# Altium schematic sheet left_led_gaze.SchDoc
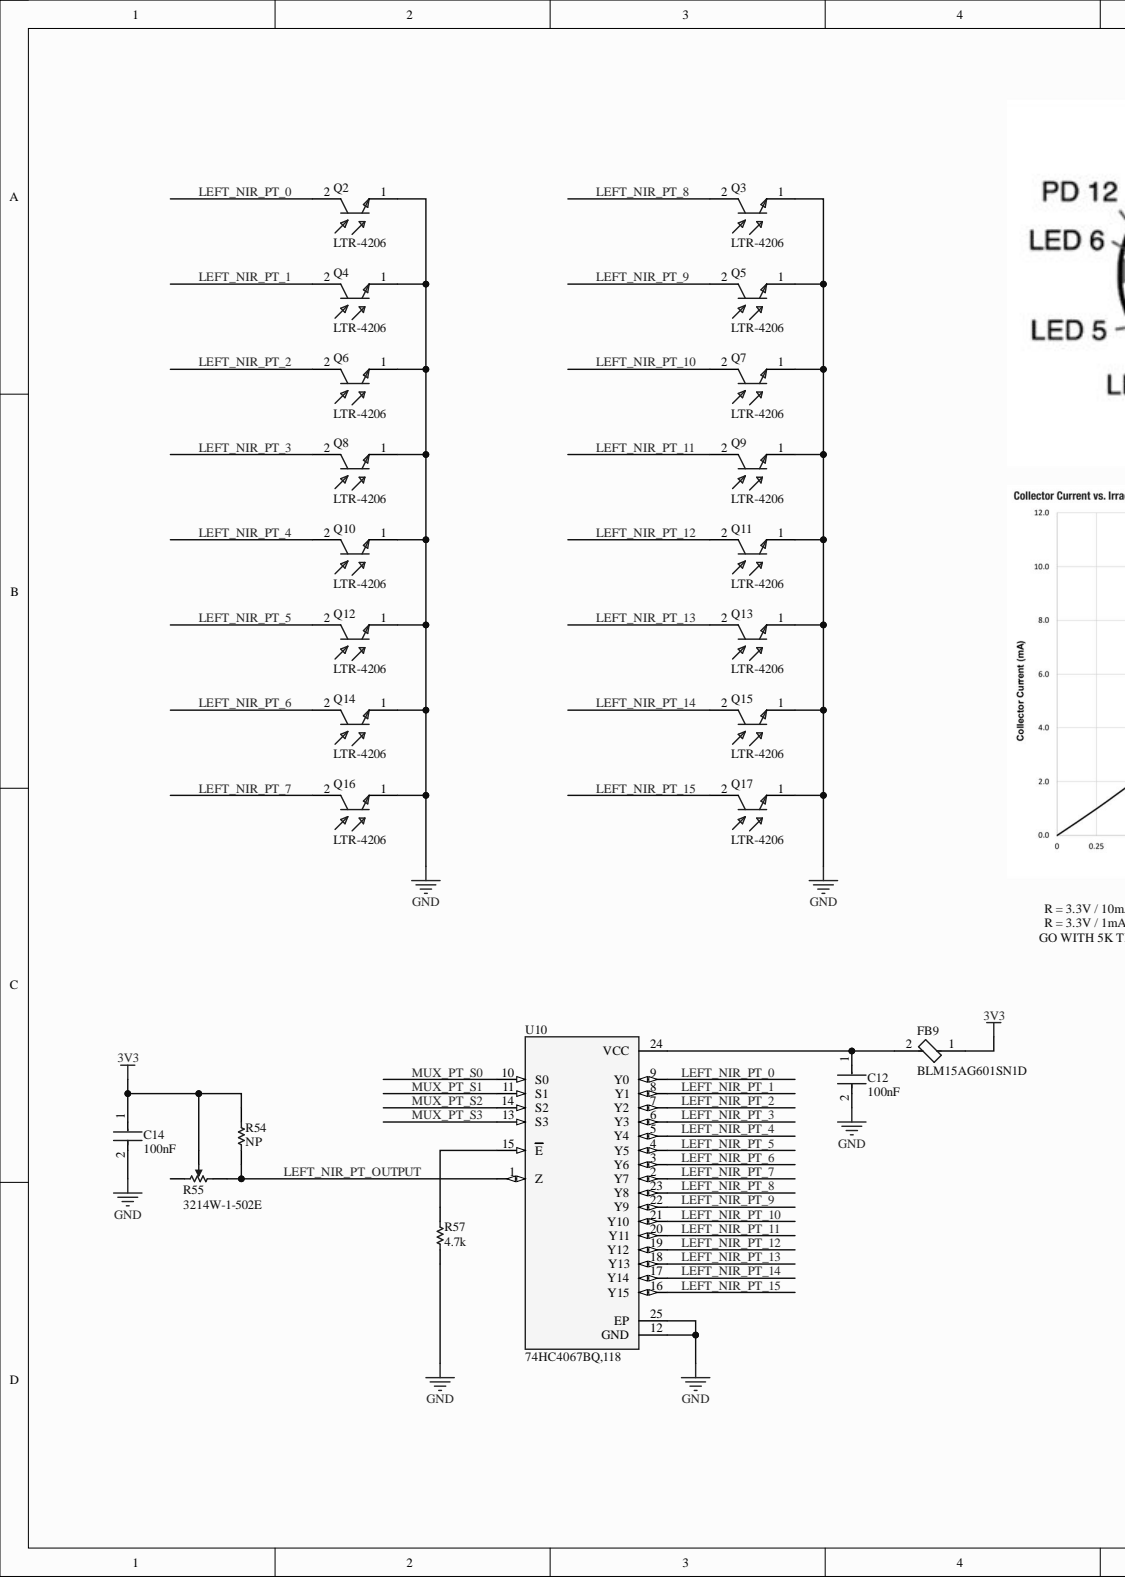
[diagram p0: left_led_gaze.SchDoc, left]
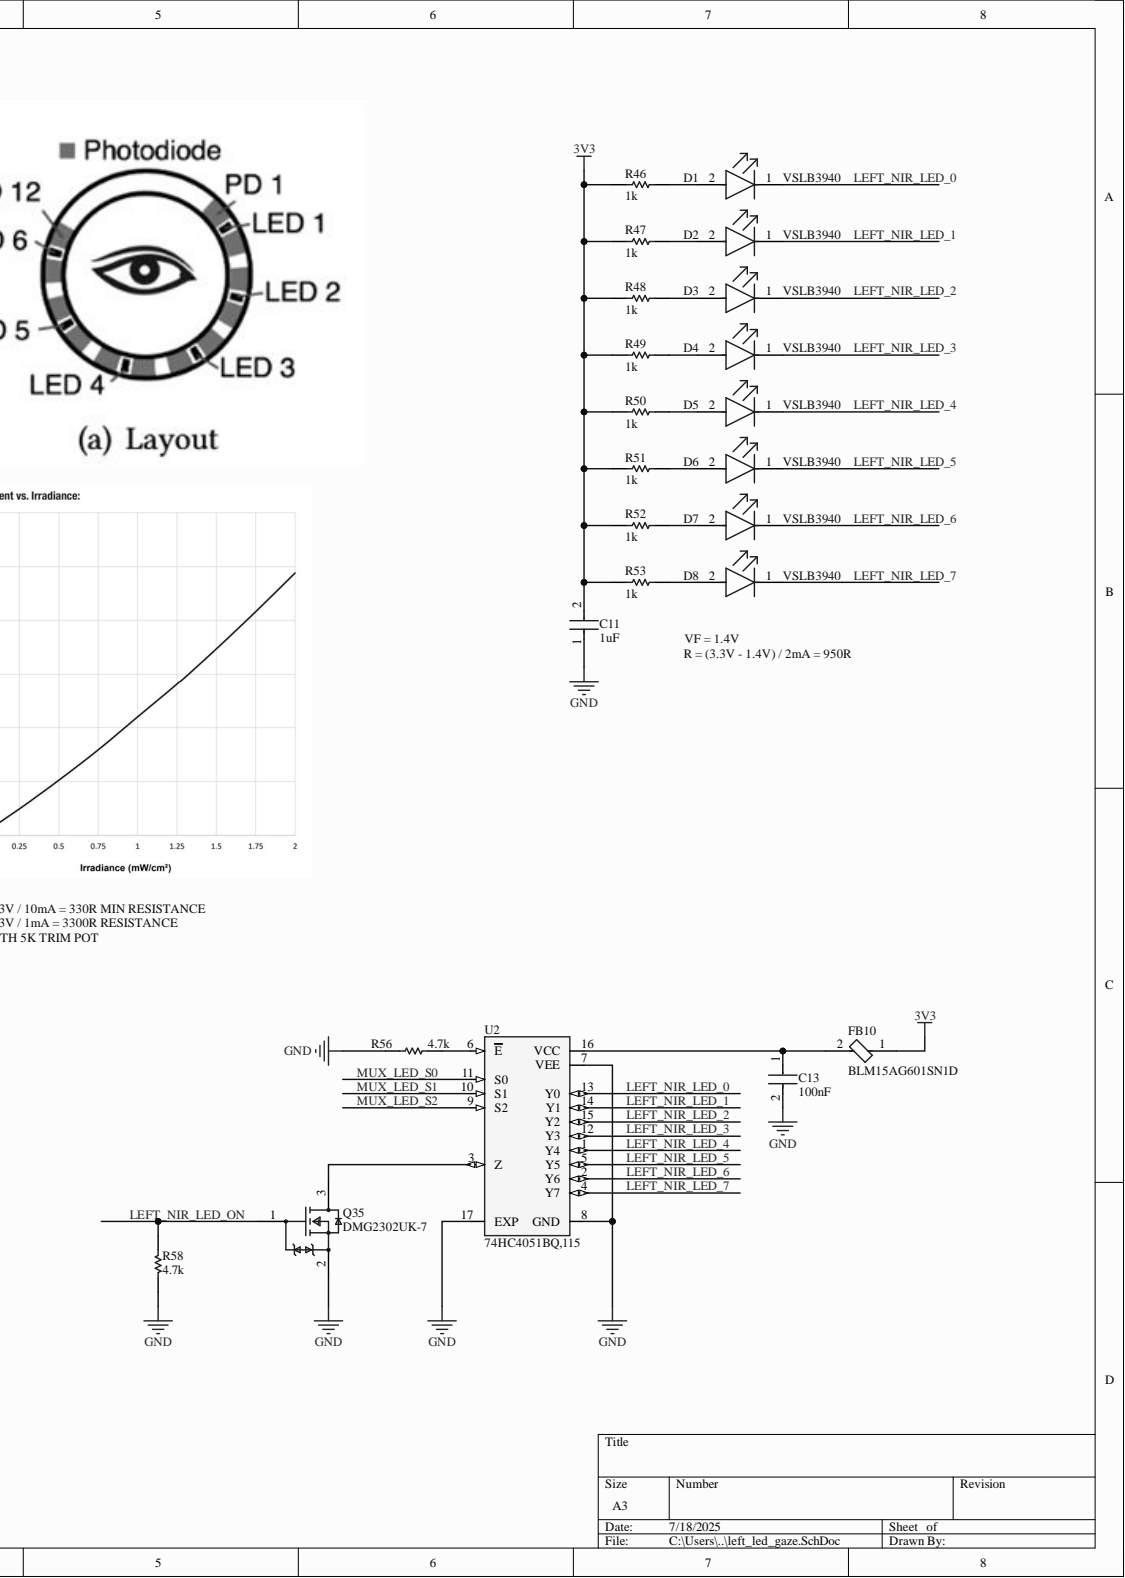
[diagram p1: left_led_gaze.SchDoc, right]

## Parts
C11  value 1uF  [FP-CC0402-MFG]  (hidden comment: CC0402KRX5R5BB105)
C12  Capacitance: 100nF  [FP-GRM15-MFG]  (hidden comment: GRM155R71C104KA88D)
C13  Capacitance: 100nF  [FP-GRM15-MFG]  (hidden comment: GRM155R71C104KA88D)
C14  Capacitance: 100nF  [FP-GRM15-MFG]  (hidden comment: GRM155R71C104KA88D)
D1  VSLB3940  [FP-VSLB3940-MFG]
D2  VSLB3940  [FP-VSLB3940-MFG]
D3  VSLB3940  [FP-VSLB3940-MFG]
D4  VSLB3940  [FP-VSLB3940-MFG]
D5  VSLB3940  [FP-VSLB3940-MFG]
D6  VSLB3940  [FP-VSLB3940-MFG]
D7  VSLB3940  [FP-VSLB3940-MFG]
D8  VSLB3940  [FP-VSLB3940-MFG]
FB9  BLM15AG601SN1D  [FP-BLM15-0_05-IPC_B]
FB10  BLM15AG601SN1D  [FP-BLM15-0_05-IPC_B]
Q2  LTR-4206  [FP-LTR-4206-MFG]
Q3  LTR-4206  [FP-LTR-4206-MFG]
Q4  LTR-4206  [FP-LTR-4206-MFG]
Q5  LTR-4206  [FP-LTR-4206-MFG]
Q6  LTR-4206  [FP-LTR-4206-MFG]
Q7  LTR-4206  [FP-LTR-4206-MFG]
Q8  LTR-4206  [FP-LTR-4206-MFG]
Q9  LTR-4206  [FP-LTR-4206-MFG]
Q10  LTR-4206  [FP-LTR-4206-MFG]
Q11  LTR-4206  [FP-LTR-4206-MFG]
Q12  LTR-4206  [FP-LTR-4206-MFG]
Q13  LTR-4206  [FP-LTR-4206-MFG]
Q14  LTR-4206  [FP-LTR-4206-MFG]
Q15  LTR-4206  [FP-LTR-4206-MFG]
Q16  LTR-4206  [FP-LTR-4206-MFG]
Q17  LTR-4206  [FP-LTR-4206-MFG]
Q35  DMG2302UK-7  [FP-SOT23-MFG]
R46  Resistance: 1k  [RESC1005X40X25NL05T05]  (hidden comment: ERJ-2RKF1001X)
R47  Resistance: 1k  [RESC1005X40X25NL05T05]  (hidden comment: ERJ-2RKF1001X)
R48  Resistance: 1k  [RESC1005X40X25NL05T05]  (hidden comment: ERJ-2RKF1001X)
R49  Resistance: 1k  [RESC1005X40X25NL05T05]  (hidden comment: ERJ-2RKF1001X)
R50  Resistance: 1k  [RESC1005X40X25NL05T05]  (hidden comment: ERJ-2RKF1001X)
R51  Resistance: 1k  [RESC1005X40X25NL05T05]  (hidden comment: ERJ-2RKF1001X)
R52  Resistance: 1k  [RESC1005X40X25NL05T05]  (hidden comment: ERJ-2RKF1001X)
R53  Resistance: 1k  [RESC1005X40X25NL05T05]  (hidden comment: ERJ-2RKF1001X)
R54  value NP  [RESC1005X40X25LL05T10]  (hidden comment: CRCW04024K70FKEDHP)
R55  3214W-1-502E  [BOUR-3214W_V]
R56  value 4.7k  [RESC1005X40X25LL05T10]  (hidden comment: CRCW04024K70FKEDHP)
R57  value 4.7k  [RESC1005X40X25LL05T10]  (hidden comment: CRCW04024K70FKEDHP)
R58  value 4.7k  [RESC1005X40X25LL05T10]  (hidden comment: CRCW04024K70FKEDHP)
U2  74HC4051BQ,115  [QFN50P250X350X100-17N]
U10  74HC4067BQ,118  [QFN50P550X350X100-25N410X210]

## Nets
3V3: C11.2 C14.1 FB9.1 FB10.1 R46.1 R47.1 R48.1 R49.1 R50.1 R51.1 R52.1 R53.1 R54.2 R55.2
GND: C11.1 C12.2 C13.2 C14.2 Q2.1 Q3.1 Q4.1 Q5.1 Q6.1 Q7.1 Q8.1 Q9.1 Q10.1 Q11.1 Q12.1 Q13.1 Q14.1 Q15.1 Q16.1 Q17.1 Q35.2 R56.2 R57.1 R58.1 U2.7(VEE) U2.8(GND) U2.17(EXP) U10.12(GND) U10.25(EP)
LEFT_NIR_LED_0: D1.1 U2.13(Y0)
LEFT_NIR_LED_1: D2.1 U2.14(Y1)
LEFT_NIR_LED_2: D3.1 U2.15(Y2)
LEFT_NIR_LED_3: D4.1 U2.12(Y3)
LEFT_NIR_LED_4: D5.1 U2.1(Y4)
LEFT_NIR_LED_5: D6.1 U2.5(Y5)
LEFT_NIR_LED_6: D7.1 U2.2(Y6)
LEFT_NIR_LED_7: D8.1 U2.4(Y7)
LEFT_NIR_LED_ON: Q35.1 R58.2
LEFT_NIR_PT_0: Q2.2 U10.9(Y0)
LEFT_NIR_PT_1: Q4.2 U10.8(Y1)
LEFT_NIR_PT_2: Q6.2 U10.7(Y2)
LEFT_NIR_PT_3: Q8.2 U10.6(Y3)
LEFT_NIR_PT_4: Q10.2 U10.5(Y4)
LEFT_NIR_PT_5: Q12.2 U10.4(Y5)
LEFT_NIR_PT_6: Q14.2 U10.3(Y6)
LEFT_NIR_PT_7: Q16.2 U10.2(Y7)
LEFT_NIR_PT_8: Q3.2 U10.23(Y8)
LEFT_NIR_PT_9: Q5.2 U10.22(Y9)
LEFT_NIR_PT_10: Q7.2 U10.21(Y10)
LEFT_NIR_PT_11: Q9.2 U10.20(Y11)
LEFT_NIR_PT_12: Q11.2 U10.19(Y12)
LEFT_NIR_PT_13: Q13.2 U10.18(Y13)
LEFT_NIR_PT_14: Q15.2 U10.17(Y14)
LEFT_NIR_PT_15: Q17.2 U10.16(Y15)
LEFT_NIR_PT_OUTPUT: R54.1 R55.1 U10.1(Z)
MUX_LED_S0: U2.11(S0)
MUX_LED_S1: U2.10(S1)
MUX_LED_S2: U2.9(S2)
MUX_PT_S0: U10.10(S0)
MUX_PT_S1: U10.11(S1)
MUX_PT_S2: U10.14(S2)
MUX_PT_S3: U10.13(S3)
NetC12_1: C12.1 FB9.2 U10.24(VCC)
NetC13_1: C13.1 FB10.2 U2.16(VCC)
NetD1_2: D1.2 R46.2
NetD2_2: D2.2 R47.2
NetD3_2: D3.2 R48.2
NetD4_2: D4.2 R49.2
NetD5_2: D5.2 R50.2
NetD6_2: D6.2 R51.2
NetD7_2: D7.2 R52.2
NetD8_2: D8.2 R53.2
NetQ35_3: Q35.3 U2.3(Z)
NetR56_1: R56.1 U2.6(E)
NetR57_2: R57.2 U10.15(E)

Not connected: R55 3

## Library data of the parts
C11 = CMP-2100-03591-2: CAP CER 1UF 6.3V X5R 0402; Manufacturer: YAGEO; Automotive Grade: NA; Material: Ceramic; Temperature Coefficient: 30ppm/°C; Tolerance: 0.1; Automotive: No; Capacitance: 1 uF; Category: Cap; Component Kind: Standard; ComponentLink2Description: Datasheet; ComponentLink2URL: http://www.yageo.com/documents/recent/UPY-GP_NP0_16V-to-50V_16.pdf; Device Class L1: Passive Components; Device Class L2: Capacitors; Device Class L3: Ceramic Capacitors; Digikey Description: CAP CER 1UF 6.3V X5R 0402; Footprint Url: http://www.yageo.com/exep/pages/download/literatures/UPY-C_GEN_24.pdf; Height: 0.55mm; Ipc Land Pattern Name: CAPC10050X50; Lead Free: Yes; Library Name: Altium Content Vault; Manufacturer Part Number: CC0402KRX5R5BB105; Mouser Description: Multilayer Ceramic Capacitors MLCC - SMD/SMT 1uF 6.3V X5R 10%; Octopart: https://octopart.com/cc0402krx5r5bb105-yageo-42244618; Package: CC0402; RoHS: TRUE; Temperature: -55°C to +125°C; Temperature Characteristic: X5R; Temperature Range High: +125°C; Temperature Range Low: -55°C; Voltage Rating: 6.3 V
C12-C14 = CMP-2100-03641-2: CAP CER 0.1UF 16V X7R 0402; Manufacturer: Murata; Material: Ceramic; Standoff Height: 0mm; Tolerance: 10%; Value: 0.10μF; Voltage Rating: 16Vdc; Category: Cap; Component Kind: Standard; ComponentLink2Description: Datasheet; ComponentLink2URL: https://search.murata.co.jp/Ceramy/image/img/A01X/G101/ENG/GRM155R71H471KA01-01A.pdf; Device Class L1: Passive Components; Device Class L2: Capacitors; Device Class L3: Ceramic Capacitors; Digikey Description: CAP CER 0.1UF 16V X7R 0402; Height: 0.55mm; Ipc Land Pattern Name: CAPC10050X219; Lead Free: yes; Library Name: Altium Content Vault; Manufacturer Part Number: GRM155R71C104KA88D; Mouser Description: 0402 0.1 uF 16V ±10 % Tolerance X7R SMT Multilayer Ceramic Capacitor; Octopart: https://octopart.com/grm155r71c104ka88d-murata-196880; Package: GRM15; RoHS: TRUE; Temperature: -55°C to +125°C; Temperature Characteristic: X7R; Temperature Range High: +125°C; Temperature Range Low: -55°C
D1-D8 = CMP-00030-18785862-1: VSLB3940 940 nm 100 mA ±22° Through Hole High Speed Infrared Emitting Diode - T-1; Fall Time: 15ns; Forward Current: 100mA; Forward Voltage: 1.35V; Lens Style: Circular, Diffused, Round; Max Power Dissipation: 160mW; Number of Elements: 1; Peak Wavelength: 940nm; Reverse Voltage: 5V; Viewing Angle: 44°; Case/Package: T; Component Kind: Standard; Depth: 3.2mm; Lead Free: Lead Free; Length: 3.2mm; Library Name: Altium Content Vault; Max Operating Temperature: 85°C; Min Operating Temperature: -25°C; Mount: Through Hole; NexarPartId: 18785862; Number of LEDs: 1; Number of Pins: 2; Orientation: Top View; Packaging: Bulk; Power Dissipation: 160mW; Power Rating: 160mW; Radiation Hardening: No; REACH SVHC: Unknown; Rise Time: 15ns; RoHS: Compliant; Test Current: 100mA; Wavelength: 940nm
FB9 FB10 = CMP-0686-00187-4: Chip Ferrite Bead, 0402, 600Ω @ 100MHz, 0.52Ω, 25%, 300mA; DC Resistance (DCR): 520mR; DC Resistance-Max: 600mR; Impedance: 600R; Material: Steel; Number of Circuits: 1; Shielding: Unshielded; Case Code (Imperial): 0402; Case Code (Metric): 1005; Case/Package: 0402; Component Kind: Standard; Core Material: Ferrite; Current Rating: 300mA; DC Current: 300mA; Depth: 0.55mm; Frequency: 100MHz; Height: 0.5mm; Lead Free: Lead Free; Length: 1mm; Library Name: Altium Content Vault; Max Current Rating: 300mA; Max DC Current: 300mA; Max Operating Temperature: 125°C; Military Standard: Not; Min Operating Temperature: -55°C; Number of Lines: 1; Number of Pins: 2; Package Quantity: 10000; Packaging: Tape and Reel; Radiation Hardening: No; REACH SVHC: No SVHC; RoHS Compliant: Yes; Series: BLM15AG_SN; Termination: SMD/SMT; Test Frequency: 100MHz; Tolerance: 25%; Width: 0.5mm
Q2-Q17 = CMP-22030-000001-1: SENSOR PHOTO 940NM TOP VIEW T1; Manufacturer: Lite-On; Collector Emitter Breakdown Voltage: 30V; Dark Current: 0.1uA; Fall Time: 10us; Max Collector Current: 9.6mA; Power Dissipation: 100mW; Viewing Angle: 20°; Wavelength: 900nm; Automotive: No; Category: Trans; Component Kind: Standard; ComponentLink2Description: Datasheet; ComponentLink2URL: http://optoelectronics.liteon.com/upload/download/DS-50-92-0072/R4206.pdf; Device Class L1: Sensors; Device Class L2: Optical Sensors; Device Class L3: Phototransistor; Digikey Description: SENSOR PHOTO 940NM TOP VIEW T1; Height: 6.65mm; Lead Free: Yes; Led Orientation: Top View; Library Name: Altium Content Vault; Manufacturer Part Number: LTR-4206; Mouser Description: Phototransistors Phototrans Clear; Octopart: https://octopart.com/ltr-4206-lite-on-707502; Package: LTR-4206; Rise Time: 10us; RoHS: TRUE; Temperature: -40°C to +85°C; Temperature Range High: +85°C; Temperature Range Low: -40°C
Q35 = CMP-12801-000001-1: MOSFET N-CH 20V 2.8A SOT23; Manufacturer: Diodes Inc.; Automotive Grade: Grade 0; Depletion Mode: False; Drain To Source Resistance: 61mΩ; Gate Charge At Vgs: 2.8nC @ 10V; Gate To Source Voltage: 12V; Input Capacitace At Vds: 130pF @ 10V; Max Forward Diode Voltage: 1.2V; Max Junction Temp: +150°C; Number Of Channels: 1; Power Dissipation: 0.66W; Pulse Drain Current: 12A; Reverse Recovery Charge: 0.5nC; Reverse Recovery Time: 5.3ns; Rthja Max: 192°C/W; Standoff Height: 0.013mm; Threshold Vgs Max: 1V; Threshold Vgs Min: 0.3V; Turn Off Delay Time: 4.2ns; Turn On Delay Time: 0.6ns; Typ Forward Diode Voltage: 0.7V; Automotive: Yes; Category: Trans; Component Kind: Standard; ComponentLink2Description: Datasheet; ComponentLink2URL: https://www.diodes.com/assets/Datasheets/DMG2302UK.pdf; Continuous Drain Current: 2.8A; Device Class L1: Discrete Semiconductors; Device Class L2: Transistors; Device Class L3: MOSFETs; Digikey Description: MOSFET N-CH 20V 2.8A SOT23; Drain To Source Breakdown Voltage: 20V; Drain To Source Voltage: 20V; Footprint Url: https://www.diodes.com/assets/Package-Files/SOT23.pdf; Height: 1.1mm; Lead Free: Yes; Library Name: Altium Content Vault; Manufacturer Part Number: DMG2302UK-7; Number Of N Channels: 1; Octopart: https://octopart.com/dmg2302uk-7-diodes+inc.-73850182; Package: SOT23; RoHS: TRUE; Temperature: -55°C to +150°C; Temperature Range High: +150°C; Temperature Range Low: -55°C
R46-R53 = CMP-1011-00510-4: Chip Resistor, 1 KOhm, +/- 1%, 100 mW, -55 to 155 degC, 0402 (1005 Metric), RoHS, Tape and Reel; Manufacturer: Basic Passives; Case\/Package: 0402; Power Rating: 100 mW; Voltage Rating: 50 V; Case Code (Imperial): 0402; Case Code (Metric): 1005; Component Kind: Standard; ComponentLink1Description: Manufacturer URL; ComponentLink1URL: http://www.panasonic.com/; ComponentLink2Description: Datasheet; ComponentLink2URL: http://industrial.panasonic.com/cdbs/www-data/pdf/RDA0000/AOA0000C86.pdf; DatasheetVersion: 04/2015; Height: 0.35 mm; Length: 1 mm; Library Name: Altium Content Vault; Max Operating Temperature: 155 degC; Min Operating Temperature: -55 degC; Mount: Surface Mount; Mounting Technology: Surface Mount; Package Quantity: 10000; PackageDescription: 2-Pin Surface Mount Device, Body 1 x 0.5 mm; PackageReference: 0402; Packaging: Tape and Reel; PartNumber: ERJ-2RKF1001X; Pins: 2; Radiation Hardening: No; REACH SVHC: Yes; RoHSCompliant: true; Tolerance: 1%; Width: 0.5 mm
R54 R56-R58 = CMP-2002-03050-1: Manufacturer: Vishay Dale; Component Kind: Standard; Library Name: Altium Content Vault; Part Number: CRCW04024K70FKEDHP
R55 = CMP-2000-05579-1: 3214 - 5-Turn Trimpot(R) Trimming Potentiometer, 5 KOhm, +/- 10%, 0.25 W, -65 to 150 degC, RoHS, Tape and Reel; Manufacturer: Bourns; No. of Turns: 5; Potentiometer Mounting: SMD; Power Rating: 250mW; SVHC: NoSVHC(16-Jun-2014); Temperature Coefficient: ±100ppm/°C; Track Resistance: 5kohm; Component Kind: Standard; Datasheet URL: http://www.bourns.com/docs/Product-Datasheets/3214.pdf; Datasheet Version: 05/2016; Library Name: Altium Content Vault; Manufacturer URL: http://www.bourns.com/; Mounting Technology: SurfaceMount; Package Description: 3-Pin Surface Mount Device, Body 3.5 x 4.8 mm, Pitch 1.25 mm; Package Reference: 3214W; Resistance Tolerance: ±10%; ROHS COMPLIANT: Yes
U2 = 74HC4051BQ,115: 1 Circuit IC Switch 8:1 120Ohm 16-DHVQFN (2.5x3.5); MF: Nexperia USA Inc.; Check_prices: https://www.snapeda.com/parts/74HC4051BQ,115/Nexperia/view-part/?ref=eda; Component Kind: Standard; DigiKey_Part_Number: 1727-6049-2-ND; Library Name: 74HC4051BQ_115.IntLib; MP: 74HC4051BQ,115; Package: DHVQFN16-16 Nexperia USA Inc.; Purchase-URL: https://pricing.snapeda.com/search?q=74HC4051BQ,115&ref=eda; SnapEDA_Link: https://www.snapeda.com/parts/74HC4051BQ,115/Nexperia/view-part/?ref=snap
U10 = 74HC4067BQ,118: 1 Circuit IC Switch 16:1 120Ohm 24-DHVQFN (5.5x3.5); MF: Nexperia USA Inc.; Check_prices: https://www.snapeda.com/parts/74HC4067BQ,118/Nexperia/view-part/?ref=eda; Component Kind: Standard; Library Name: 74HC4067BQ_118.IntLib; MP: 74HC4067BQ,118; Package: DHVQFN24-24 Nexperia; SnapEDA_Link: https://www.snapeda.com/parts/74HC4067BQ,118/Nexperia/view-part/?ref=snap
